# Altium schematic sheet Sheet2.SchDoc(Sheet2)
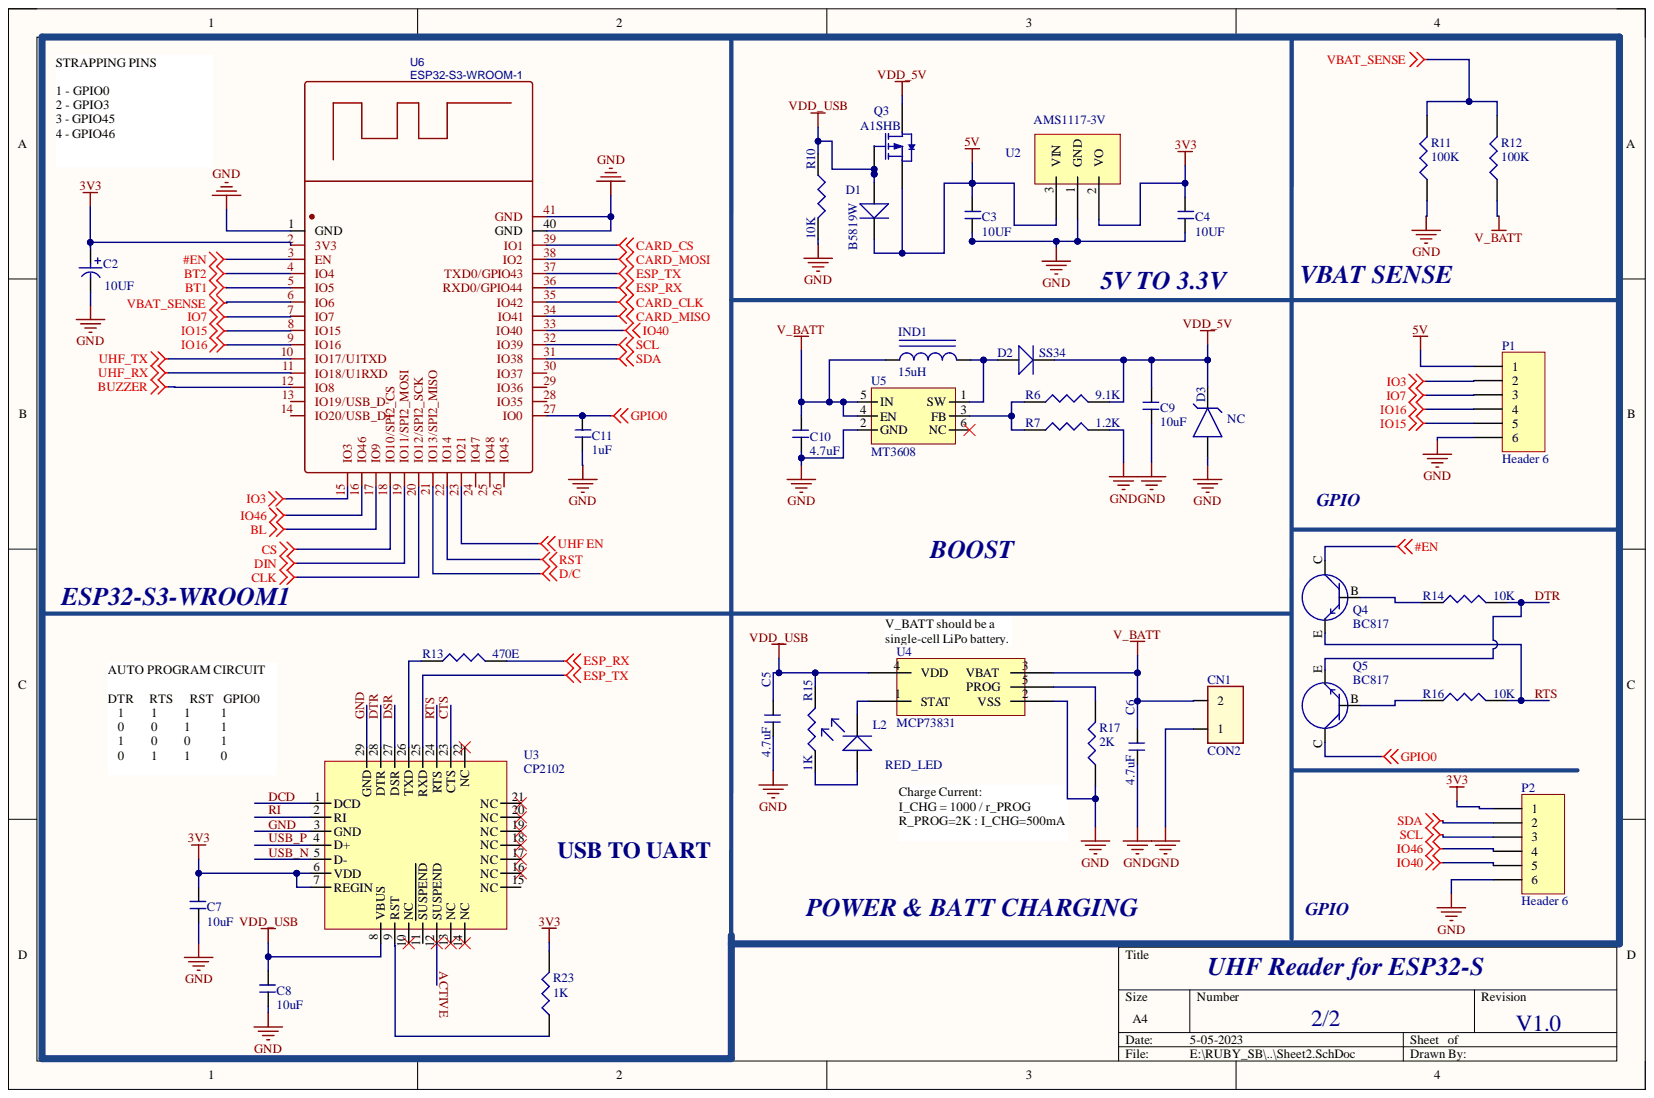
[diagram p0: Sheet2.SchDoc]

## Parts
C2  10UF  [C_0603]
C3  10UF  [tantlum caseA]
C4  10UF  [tantlum caseA]
C5  4.7uF  [C_0603]
C6  4.7uF  [C_0603]
C7  10uF  [C_0603]
C8  10uF  [C_0603]
C9  10uF  [C_0603]
C10  4.7uF  [C_0603]
C11  1uF  [C_0603]
CN1  CON2  [JST2P_P2.0mm]
D1  B5819W  [SOD-123]
D2  SS34  [SS34]
D3  NC  [SS34]
IND1  15uH  [IND 15UH]
L2  RED_LED  [LED_0603]
P1  Header 6  [6P_2.54MM]
P2  Header 6  [6P_2.54MM]
Q3  A1SHB  [BSS84]
Q4  BC817  [SOT23]
Q5  BC817  [SOT23]
R6  9.1K  [R_0603]
R7  1.2K  [R_0603]
R10  10K  [R_0603]
R11  100K  [R_0603]
R12  100K  [R_0603]
R13  470E  [R_0603]
R14  10K  [R_0603]
R15  1K  [R_0603]
R16  10K  [R_0603]
R17  2K  [R_0603]
R23  1K  [R_0603]
U2  AMS1117-3V  [AMS1117_3V3]
U3  CP2102  [CP2102]
U4  MCP73831  [SOT23-5]
U5  MT3608  [SOT23-6]
U6  ESP32-S3-WROOM-1  [ESP32-S3 WROOM 1]

## Nets
3V3: C2.1 C4.2 C7.1 P2.1 R23.2 U2.2 U3.6(VDD) U3.7(REGIN) U6.2(3V3)
5V: C3.2 D1.K P1.1 Q3.2 U2.3
#EN: Q4.C U6.3(EN)
BL: U6.17(IO9)
BT1: U6.5(IO5)
BT2: U6.4(IO4)
BUZZER: U6.12(IO8)
CARD_CLK: U6.35(IO42)
CARD_CS: U6.39(IO1)
CARD_MISO: U6.34(IO41)
CARD_MOSI: U6.38(IO2)
CLK: U6.20(IO12/SPI2_SCK)
CS: U6.18(IO10/SPI2_CS)
D/C: U6.21(IO13/SPI2_MISO)
DIN: U6.19(IO11/SPI2_MOSI)
ESP_RX: R13.2 U6.36(RXD0/GPIO44)
ESP_TX: U3.25(RXD) U6.37(TXD0/GPIO43)
GPIO0: C11.2 Q5.C U6.27(IO0)
IO3: P1.2 U6.15(IO3)
IO7: P1.3 U6.7(IO7)
IO15: P1.5 U6.8(IO15)
IO16: P1.4 U6.9(IO16)
IO40: P2.5 U6.33(IO40)
IO46: P2.4 U6.16(IO46)
RST: U6.22(IO14)
SCL: P2.3 U6.32(IO39)
SDA: P2.2 U6.31(IO38)
UHF EN: U6.23(IO21)
UHF_RX: U6.11(IO18/U1RXD)
UHF_TX: U6.10(IO17/U1TXD)
V_BATT: C6.1 C10.1 CN1.2 IND1.1 R12.1 U4.3(VBAT) U5.4(EN) U5.5(IN)
VBAT_SENSE: R11.2 R12.2 U6.6(IO6)
VDD_5V: C9.1 D2.K D3.K Q3.3 R6.2
VDD_USB: C5.1 C8.1 D1.A Q3.1 R10.1 R15.2 U3.8(VBUS) U4.4(VDD)
ACTIVE: U3.12(SUSPEND)
CTS: U3.23(CTS)
DCD: U3.1(DCD)
DSR: U3.27(DSR)
DTR: Q5.E R14.2 U3.28(DTR)
GND: C2.2 C3.1 C4.1 C5.2 C6.2 C7.2 C8.2 C9.2 C10.2 C11.1 CN1.1 D3.A P1.6 P2.6 R7.2 R10.2 R11.1 R17.1 U2.1 U3.3(GND) U3.29(GND) U4.2(VSS) U5.2(GND) U6.1(GND) U6.40(GND) U6.41(GND)
RI: U3.2(RI)
RTS: Q4.E R16.2 U3.24(RTS)
USB_N: U3.5(D-)
USB_P: U3.4(D+)
NetD2_A: D2.A IND1.2 U5.1(SW)
NetL2_A: L2.A R15.1
NetL2_K: L2.K U4.1(STAT)
NetQ4_B: Q4.B R14.1
NetQ5_B: Q5.B R16.1
NetR6_1: R6.1 R7.1 U5.3(FB)
NetR13_1: R13.1 U3.26(TXD)
NetR17_2: R17.2 U4.5(PROG)
NetR23_1: R23.1 U3.9(RST)

Not connected: U3 10(NC) 11(SUSPEND) 13(NC) 14(NC) 15(NC) 16(NC) 17(NC) 18(NC) 19(NC) 20(NC) 21(NC) 22(NC); U5 6(NC); U6 13(IO19/USB_D-) 14(IO20/USB_D+) 24(IO47) 25(IO48) 26(IO45) 28(IO35) 29(IO36) 30(IO37)

## Library data of the parts
C2 = CAP_ELE: Component Kind: Standard; Library Name: MIX.SchLib
C3-C11 = CAP_NONPOLAR: Component Kind: Standard; Library Name: dxp_pasl_lib.IntLib
D1 D2 = DIODE: Component Kind: Standard; Library Name: dxp_pasl_lib.IntLib
D3 = ZENER DIODE: Component Kind: Standard; Library Name: dxp_pasl_lib.IntLib
IND1 = INDUCTOR: Component Kind: Standard; Library Name: dxp_pasl_lib.IntLib
L2 = LED: Component Kind: Standard; Library Name: dxp_pasl_lib.IntLib
P1 P2 = Header 6: Header, 6-Pin; Component Kind: Standard; LatestRevisionDate: 17-Jul-2002; LatestRevisionNote: Re-released for DXP Platform.; Library Name: Miscellaneous Connectors.IntLib; Signal Integrity: Connector; Publisher: Altium Limited
Q3 = BSS84: 50V 130mA 250mW 10Ω 10V,130mA 2V 1mA P Channel SOT-23(SOT-23-3) MOSFETs ROHS #C493579; PackageDocument: 1995/1996; CATEGORY: MOSFET; Component Kind: Standard; LatestRevisionDate: 17-Jul-2002; LatestRevisionNote: Re-released for DXP Platform.; PackageReference: E3; Published: 8-Jun-2000; Library Name: dxp_pasl_lib.IntLib; Publisher: Altium Limited
Q4 Q5 = TRANSISTER_NPN: Component Kind: Standard; Library Name: dxp_pasl_lib.IntLib
R6 R7 R10-R17 R23 = RES: Component Kind: Standard; Library Name: dxp_pasl_lib.IntLib
U2 = AMS1117: Component Kind: Standard; Library Name: dxp_pasl_lib.IntLib
U3 = CP2102_1: QFN, 28-Leads, Body 5.0x5.0mm (max), Pitch 0.50mm, IPC Medium Density; Component Kind: Standard; Library Name: dxp_pasl_lib.IntLib
U5 = MT3608: 28V~28V 2V~24V 1.2MHz SOT-23-6 DC-DC Converters ROHS #C84817; Component Kind: Standard; Library Name: dxp_pasl_lib.IntLib
U6 = ESP32-S3-WROOM-1(N16): BOM_Manufacturer: ESPRESSIF(乐鑫); BOM_Manufacturer Part: ESP32-S3-WROOM-1-N16; Contributor: lcsc; BOM_JLCPCB Part Class: Extended Part; BOM_Supplier: LCSC; BOM_Supplier Part: C2913199; Component Kind: Standard; link: https://atta.szlcsc.com/upload/public/pdf/source/20220628/A2AC12B3C87735CAEB7EBE2DBE80936C.pdf; spicePre: U; spiceSymbolName: ESP32-S3-WROOM-1(N16)
CN1 = CON2: Component Kind: Standard; Library Name: dxp_pasl_lib.IntLib
U4 = MCP73831: Component Kind: Standard; Library Name: dxp_pasl_lib.IntLib
